# Altium schematic sheet Sheet1.SchDoc
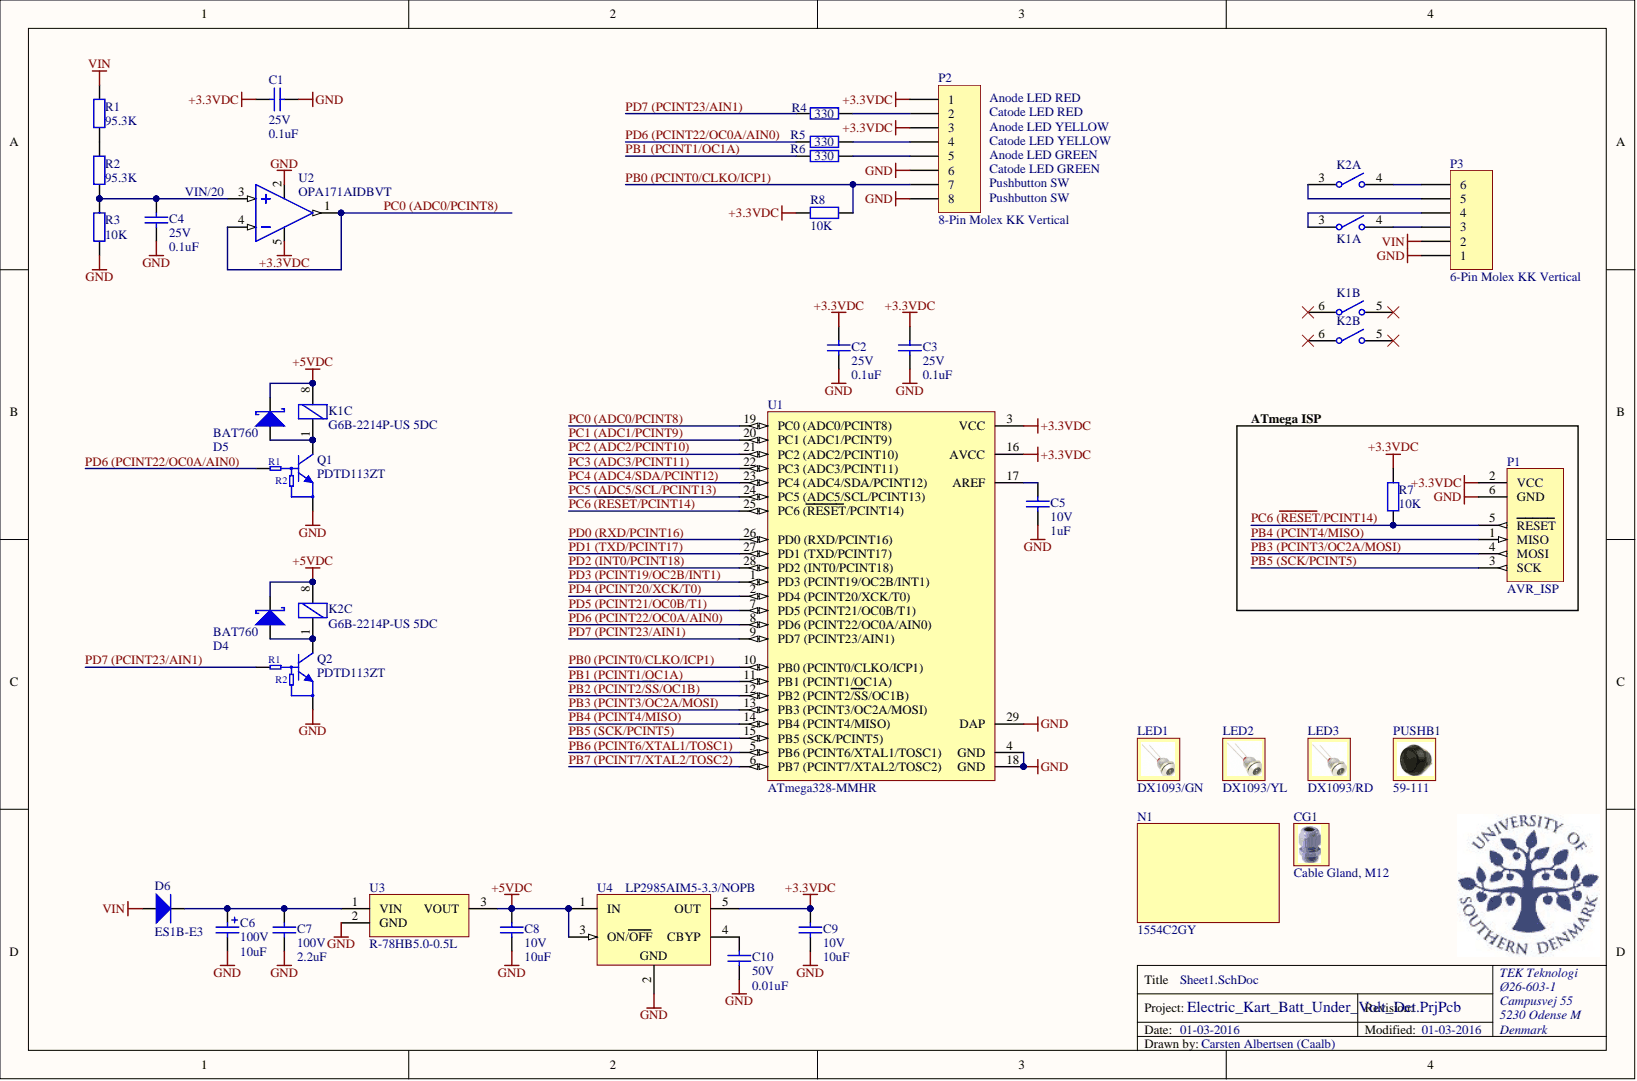
[diagram p0: Sheet1.SchDoc]

## Parts
C1  25V  value 0.1uF  [0603C]
C2  25V  value 0.1uF  [0603C]
C3  25V  value 0.1uF  [0603C]
C4  25V  value 0.1uF  [0603C]
C5  10V  value 1uF  [0603C]
C6  value 10uF  Voltage: 100V  [ELYT SIZE E]  (hidden comment: EEE2AA100UP)
C7  100V  value 2.2uF  [1210C_H]
C8  10V  value 10uF  [0805C]
C9  10V  value 10uF  [0805C]
C10  50V  value 0.01uF  [0603C]
CG1  Cable Gland, M12  (mechanical)
D4  BAT760  [SOD323]
D5  BAT760  [SOD323]
D6  ES1B-E3  [DO-214AC]
K1  G6B-2214P-US 5DC  [G6B-2214C-US]  (parts A,B,C)
K2  G6B-2214P-US 5DC  [G6B-2214C-US]  (parts A,B,C)
LED1  DX1093/GN  (mechanical)
LED2  DX1093/YL  (mechanical)
LED3  DX1093/RD  (mechanical)
N1  1554C2GY  [1554C2GY]
P1  AVR_ISP  [HDR2X3 FLAD]
P2  8-Pin Molex KK Vertical  [Molex HDR1x8]
P3  6-Pin Molex KK Vertical  [Molex HDR1x6]
PUSHB1  59-111  (mechanical)
Q1  PDTD113ZT  [sot23]
Q2  PDTD113ZT  [sot23]
R1  value 95.3K  [0603R]  (hidden comment: 1%)
R2  value 95.3K  [0603R]  (hidden comment: 1%)
R3  1%  value 10K  [0603R]
R4  value 330  [0603R]  (hidden comment: 1%)
R5  value 330  [0603R]  (hidden comment: 1%)
R6  value 330  [0603R]  (hidden comment: 1%)
R7  1%  value 10K  [0603R]
R8  1%  value 10K  [0603R]
U1  ATmega328-MMHR  [28M1_N]
U2  OPA171AIDBVT  [SOT23-5]
U3  R-78HB5.0-0.5L  [R-78HBX.X-0.5L]
U4  LP2985AIM5-3.3/NOPB  [sot23-5]

## Nets
+3.3VDC: C1.2 C2.2 C3.2 C9.2 P1.2(VCC) P2.1 P2.3 R7.2 R8.1 U1.3(VCC) U1.16(AVCC) U2.5 U4.5(OUT)
+5VDC: C8.1 D4.1 D5.1 K1.8 K2.8 U3.3 U4.1(IN) U4.3(ON/OFF)
GND: C1.1 C2.1 C3.1 C4.1 C5.2 C6.2 C7.1 C8.2 C9.1 C10.1 P1.6(GND) P2.6 P2.8 P3.1 Q1.2 Q2.2 R3.2 U1.4(GND) U1.18(GND) U1.29(DAP) U2.2 U3.2 U4.2
VIN: D6.1 P3.2 R1.1
PB0 (PCINT0/CLKO/ICP1): P2.7 R8.2 U1.10(PB0_(PCINT0/CLKO/ICP1))
PB1 (PCINT1/OC1A): R6.1 U1.11(PB1_(PCINT1/OC1A))
PB2 (PCINT2/~{SS}/OC1B): U1.12(PB2_(PCINT2/SS/OC1B))
PB3 (PCINT3/OC2A/MOSI): P1.4(MOSI) U1.13(PB3_(PCINT3/OC2A/MOSI))
PB4 (PCINT4/MISO): P1.1(MISO) U1.14(PB4_(PCINT4/MISO))
PB5 (SCK/PCINT5): P1.3(SCK) U1.15(PB5_(SCK/PCINT5))
PB6 (PCINT6/XTAL1/TOSC1): U1.5(PB6_(PCINT6/XTAL1/TOSC1))
PB7 (PCINT7/XTAL2/TOSC2): U1.6(PB7_(PCINT7/XTAL2/TOSC2))
PC0 (ADC0/PCINT8): U1.19(PC0_(ADC0/PCINT8)) U2.1 U2.4
PC1 (ADC1/PCINT9): U1.20(PC1_(ADC1/PCINT9))
PC2 (ADC2/PCINT10): U1.21(PC2_(ADC2/PCINT10))
PC3 (ADC3/PCINT11): U1.22(PC3_(ADC3/PCINT11))
PC4 (ADC4/SDA/PCINT12): U1.23(PC4_(ADC4/SDA/PCINT12))
PC5 (ADC5/SCL/PCINT13): U1.24(PC5_(ADC5/SCL/PCINT13))
PC6 (~{RESET}/PCINT14): P1.5(RESET) R7.1 U1.25(PC6_(RESET/PCINT14))
PD0 (RXD/PCINT16): U1.26(PD0_(RXD/PCINT16))
PD1 (TXD/PCINT17): U1.27(PD1_(TXD/PCINT17))
PD2 (INT0/PCINT18): U1.28(PD2_(INT0/PCINT18))
PD3 (PCINT19/OC2B/INT1): U1.1(PD3_(PCINT19/OC2B/INT1))
PD4 (PCINT20/XCK/T0): U1.2(PD4_(PCINT20/XCK/T0))
PD5 (PCINT21/OC0B/T1): U1.7(PD5_(PCINT21/OC0B/T1))
PD6 (PCINT22/OC0A/AIN0): Q1.1 R5.1 U1.8(PD6_(PCINT22/OC0A/AIN0))
PD7 (PCINT23/AIN1): Q2.1 R4.1 U1.9(PD7_(PCINT23/AIN1))
NetC4_2: C4.2 R2.2 R3.1 U2.3
NetC5_1: C5.1 U1.17(AREF)
NetC6_1: C6.1 C7.2 D6.2 U3.1
NetC10_2: C10.2 U4.4(CBYP)
NetD4_2: D4.2 K2.1 Q2.3
NetD5_2: D5.2 K1.1 Q1.3
NetK1_3: K1.3 P3.4
NetK1_4: K1.4 P3.3
NetK2_3: K2.3 P3.5
NetK2_4: K2.4 P3.6
NetP2_2: P2.2 R4.2
NetP2_4: P2.4 R5.2
NetP2_5: P2.5 R6.2
NetR1_2: R1.2 R2.1

Not connected: K1 5 6; K2 5 6

## Library data of the parts
C1-C4 = Cap_0603_.1uF: Capacitor, SMD, 0603, X7R; Component Kind: Standard; Signal Integrity: Cap; Simulation: CAP; Supplier 1: RS-Components; Supplier 2: Farnell; Supplier 3: Digi-Key; Supplier 4: Mouser; Library Name: Ofte_Benyttede.SCHLIB
C5 = Cap_0603_1uF: Capacitor, SMD, 0603, X5R; Component Kind: Standard; Signal Integrity: Cap; Simulation: CAP; Supplier 1: RS-Components; Supplier 2: Farnell; Supplier 3: Digi-Key; Supplier 4: Mouser; Library Name: Ofte_Benyttede.SCHLIB
C6 = EEE2AA100UP: Elektrolyt,  10uF, 100V; Component Kind: Standard; ComponentLink1Description: http://media.digikey.com/pdf/Data%20Sheets/Panasonic%20Capacitors%20PDFs/EEE_S_A.pdf; ComponentLink1URL: http://media.digikey.com/pdf/Data%20Sheets/Panasonic%20Capacitors%20PDFs/EEE_S_A.pdf; Supplier 1: RS-Components; Supplier 2: Farnell; Supplier 3: Digi-Key; Supplier 4: Mouser; Supplier Part Number 1: 07-8004; Supplier Part Number 2: 2326184; Supplier Part Number 3: PCE3966CT-ND; Library Name: Panasonic_egne.SchLib
C7 = Cap_1210_2.2uF: Capacitor, SMD, 1210, X7R; Component Kind: Standard; ComponentLink1Description: http://www.tdk.com/pdf/mid_volt_B11.pdf; ComponentLink1URL: http://www.tdk.com/pdf/mid_volt_B11.pdf; Signal Integrity: Cap; Simulation: CAP; Supplier 1: RS-Components; Supplier 2: Farnell; Supplier 3: Digi-Key; Supplier 4: Mouser; Supplier Part Number 1: 698-3765; Supplier Part Number 3: 445-4497-1-ND; Library Name: Ofte_Benyttede.SCHLIB
C8 C9 = Cap_0805_10uF: Capacitor, SMD, 0805, X5R; Component Kind: Standard; ComponentLink1Description: http://search.murata.co.jp/Ceramy/image/img/PDF/ENG/GRM21BR61A106KE19.pdf; ComponentLink1URL: http://search.murata.co.jp/Ceramy/image/img/PDF/ENG/GRM21BR61A106KE19.pdf; Signal Integrity: Cap; Simulation: CAP; Supplier 1: RS-Components; Supplier 2: Farnell; Supplier 3: Digi-Key; Supplier 4: Mouser; Supplier Part Number 1: 106-846; Supplier Part Number 3: 490-1709-1-ND; Library Name: Ofte_Benyttede.SCHLIB
C10 = Cap_0603_.01uF: Capacitor, SMD, 0603, X7R; Component Kind: Standard; Signal Integrity: Cap; Simulation: CAP; Supplier 1: RS-Components; Supplier 2: Farnell; Supplier 3: Digi-Key; Supplier 4: Mouser; Library Name: Ofte_Benyttede.SCHLIB
CG1 = 50.612 PA/4X2: Cable Gland, M12 x 1.5, Nylon 6 (Polyamide 6), Grey; ComponentLink1Description: http://www.farnell.com/datasheets/1696609.pdf; ComponentLink1URL: http://www.farnell.com/datasheets/1696609.pdf; Supplier 1: RS-Components; Supplier 2: Farnell; Supplier 3: Digi-Key; Supplier 4: Mouser; Supplier Part Number 2: 2308372; Library Name: DivLib.SCHLIB
D4 D5 = BAT760: Schottky Barrier Diode; Component Kind: Standard; ComponentLink1Description: Manufacturer Link; ComponentLink1URL: http://www.semiconductors.philips.com/; ComponentLink2Description: Datasheet; ComponentLink2URL: http://www.semiconductors.philips.com/acrobat/datasheets/BAT760_2.pdf; Signal Integrity: BAT760; Simulation: BAT760; Supplier 1: RS-Components; Supplier 2: Farnell; Supplier 3: Digi-Key; Supplier 4: Mouser; Supplier Part Number 1: 485-486; Supplier Part Number 2: 8734593; Supplier Part Number 3: BAT760DICT-ND; Library Name: Ofte_Benyttede.SCHLIB
D6 = ES1B-E3: 100V, 1A, Surface Mount Ultrafast Plastic Rectifier; Component Kind: Standard; ComponentLink1Description: http://www.vishay.com/docs/88586/es1.pdf; ComponentLink1URL: http://www.vishay.com/docs/88586/es1.pdf; Supplier 1: RS-Components; Supplier 2: Farnell; Supplier 3: Digi-Key; Supplier 4: Mouser; Supplier Part Number 1: 629-2334; Supplier Part Number 2: 1204902; Library Name: Vishay_egne.SchLib
K1 K2 = G6B-2214P-US 5DC: G6B Series, Power, Non Latching, DPST-NO, 5 VDC, 5 A; Component Kind: Standard; ComponentLink1Description: http://www.components.omron.com/components/web/PDFLIB.nsf/0/4287C4A29AD8E88785257201007DD690/$file/G6B_0911.pdf; ComponentLink1URL: http://www.components.omron.com/components/web/PDFLIB.nsf/0/4287C4A29AD8E88785257201007DD690/$file/G6B_0911.pdf; Supplier 1: RS-Components; Supplier 2: Farnell; Supplier 3: Digi-Key; Supplier 4: Mouser; Supplier Part Number 2: 9949224; Supplier Part Number 3: Z947-ND; Library Name: Omron_egne.SchLib
LED1 = DX1093/GN: LED Panel Mount Indicator, Aluminium Bezel, Green, 8 mm, 2 VDC, 25 mA, 20 mcd, IP66; Supplier 1: RS-Components; Supplier 2: Farnell; Supplier 3: Digi-Key; Supplier 4: Mouser; Supplier Part Number 2: 178172; Supplier Part Number 3: 708-1890-ND; Library Name: DivLib.SCHLIB
LED2 = DX1093/YL: LED Panel Mount Indicator, Aluminium Bezel, yellow 8 mm, 2 VDC, 30 mA, 20 mcd, IP66; Supplier 1: RS-Components; Supplier 2: Farnell; Supplier 3: Digi-Key; Supplier 4: Mouser; Supplier Part Number 2: 178173; Supplier Part Number 3: DX1093/YL-ND; Library Name: DivLib.SCHLIB
LED3 = DX1093/RD: LED Panel Mount Indicator, Aluminium Bezel, Red, 8 mm, 2 VDC, 25 mA, 25 mcd, IP66; Supplier 1: RS-Components; Supplier 2: Farnell; Supplier 3: Digi-Key; Supplier 4: Mouser; Supplier Part Number 2: 178171; Supplier Part Number 3: DX1093/RD-ND; Library Name: DivLib.SCHLIB
N1 = 1554C2GY: 120 mm, 65 mm, 40 mm, PC (Polycarbonate), IP66, Grey; Component Kind: Standard; Supplier 1: RS-Components; Supplier 2: Farnell; Supplier 3: Digi-Key; Supplier 4: Mouser; Supplier Part Number 2: 4080804; Supplier Part Number 3: 1554C2GY-ND; Library Name: DivLib.SCHLIB
P1 = AVR_ISP: AVRISP Programming connector; Component Kind: Standard; ComponentLink1Description: http://www.farnell.com/datasheets/16835.pdf; ComponentLink1URL: http://www.farnell.com/datasheets/16835.pdf; Supplier 1: RS-Components; Supplier 2: Farnell; Supplier 3: Digi-Key; Supplier 4: Mouser; Library Name: DivLib.SCHLIB
P2 = 22-27-2081: 8-Pin, 2,54 mm Molex KK serie, Vertical; Lager: No; Component Kind: Standard; Supplier 1: RS-Components; Supplier 2: Farnell; Supplier 3: Digi-Key; Supplier 4: Mouser; Supplier Part Number 1: 483-8528; Library Name: Molex_egne.SchLib
P3 = 22-27-2061: 6-Pin, 2,54 mm Molex KK serie, Vertical; Lager: No; Component Kind: Standard; Supplier 1: RS-Components; Supplier 2: Farnell; Supplier 3: Digi-Key; Supplier 4: Mouser; Supplier Part Number 1: 483-8506; Library Name: Molex_egne.SchLib
PUSHB1 = 59-111: Pushbutton Switch, SPST-NO, On-(On), 50 V, 50 V, 400 mA, Solder; Supplier 1: RS-Components; Supplier 2: Farnell; Supplier 3: Digi-Key; Supplier 4: Mouser; Supplier Part Number 2: 519315; Library Name: DivLib.SCHLIB
Q1 Q2 = PDTD113ZT: NPN 500 mA, 50 V resistor-equipped transistor 1K 10K; Component Kind: Standard; Supplier 1: RS-Components; Supplier 2: Farnell; Supplier 3: Digi-Key; Supplier 4: Mouser; Supplier Part Number 1: 508-541; Library Name: Philips_egne.SchLib
R1-R8 = Res_0603: Resistor, SMD, 0603, 0.063W; Component Kind: Standard; Signal Integrity: Res; Simulation: RESISTOR; Supplier 1: RS-Components; Supplier 2: Farnell; Supplier 3: Digi-Key; Supplier 4: Mouser; Library Name: Ofte_Benyttede.SCHLIB
  R1 R2: Supplier Part Number 2: 1170985
U1 = ATmega328-MMHR: 8-bit AVR Microcontroller, 32KB Flash, 1KB EEPROM, 2KB SRAM, 28-pin VQFN, Industrial Grade (-40°C to 85°C), Reel; Component Kind: Standard; ComponentLink1Description: Manufacturer URL; ComponentLink1URL: http://www.atmel.com/; ComponentLink2Description: ATmega48A/48PA/88A/88PA/168A/168PA/328/328P Datasheet; ComponentLink2URL: http://www.atmel.com/dyn/resources/prod_documents/8271.pdf; ComponentLink3Description: ATmega48A/48PA/88A/88PA/168A/168PA/328/328P Summary; ComponentLink3URL: http://www.atmel.com/dyn/resources/prod_documents/8271S.pdf; DatasheetVersion: revD, May-2011; Library Name: Atmel Microcontroller megaAVR.IntLib; PackageDescription: 28-pad, 4x4x1.0mm Body, Pitch 0.45mm, 2.4x2.4mm Exposed Pad, Plastic Very Thin QFN Package (VQFN); PackageReference: 28M1; PackageVersion: revB, Nov-2008
U2 = OPA171AIDBVT: 36V, Low Power, RRO, General Purpose Operational Amplifier in MicroPackages; Component Kind: Standard; ComponentLink1Description: http://focus.ti.com/lit/ds/symlink/opa171.pdf; ComponentLink1URL: http://focus.ti.com/lit/ds/symlink/opa171.pdf; Supplier 1: RS-Components; Supplier 2: Farnell; Supplier 3: Digi-Key; Supplier 4: Mouser; Supplier Part Number 1: 730-0590; Supplier Part Number 2: 1862580; Library Name: Texas_inst_egne.SchLib
U3 = R-78HB5.0-0.5L: Non Isolated POL DC/DC Converter, Switching, SIP, Through Hole, 1, 2.5 W, 5 V, 500 mA; Component Kind: Standard; ComponentLink1Description: http://www.recom-power.com/pdf/Innoline/R-78HBxx-0.5_L.pdf; ComponentLink1URL: http://www.recom-power.com/pdf/Innoline/R-78HBxx-0.5_L.pdf; Supplier 1: RS-Components; Supplier 2: Farnell; Supplier 3: Digi-Key; Supplier 4: Mouser; Supplier Part Number 1: 672-7095; Supplier Part Number 2: 2440305; Supplier Part Number 3: 945-1614-5-ND; Library Name: DivLib.SCHLIB
U4 = LP2985AIM5-3.3/NOPB: Micropower 150 mA Low-Noise Ultra Low-Dropout Regulator, 5-pin SOT-23, Pb-Free; Manufacturer: National Semiconductor; CompType: LDO IC; High-Reliability: Y; PackageTopMarking: L0RA*XTT; PowerWise: N; Component Kind: Standard; ComponentLink1Description: Manufacturer URL; ComponentLink1URL: http://www.national.com/; ComponentLink2Description: LP2985 Datasheet; ComponentLink2URL: http://www.national.com/ds/LP/LP2985.pdf; ComponentLink3Description: LP2985 Web Page; ComponentLink3URL: http://www.national.com/pf/LP/LP2985.html; ComponentLink4Description: MF05A Package Page; ComponentLink4URL: http://www.national.com/packaging/folders/mf05a.html; DatasheetVersion: Jul-2007; Library Name: National Semiconductor LDO.IntLib; PackageDescription: Molded SOT-23, .115 x .063 x .040 in Body, 5 Lead, .0375 in Pitch; PackageReference: MF05A; PackageVersion: revD, Aug-2008; PartNumber: LP2985AIM5-3.3/NOPB; PinCount: 5; RoHS: Y
